# Altium schematic sheet main.SchDoc(main)
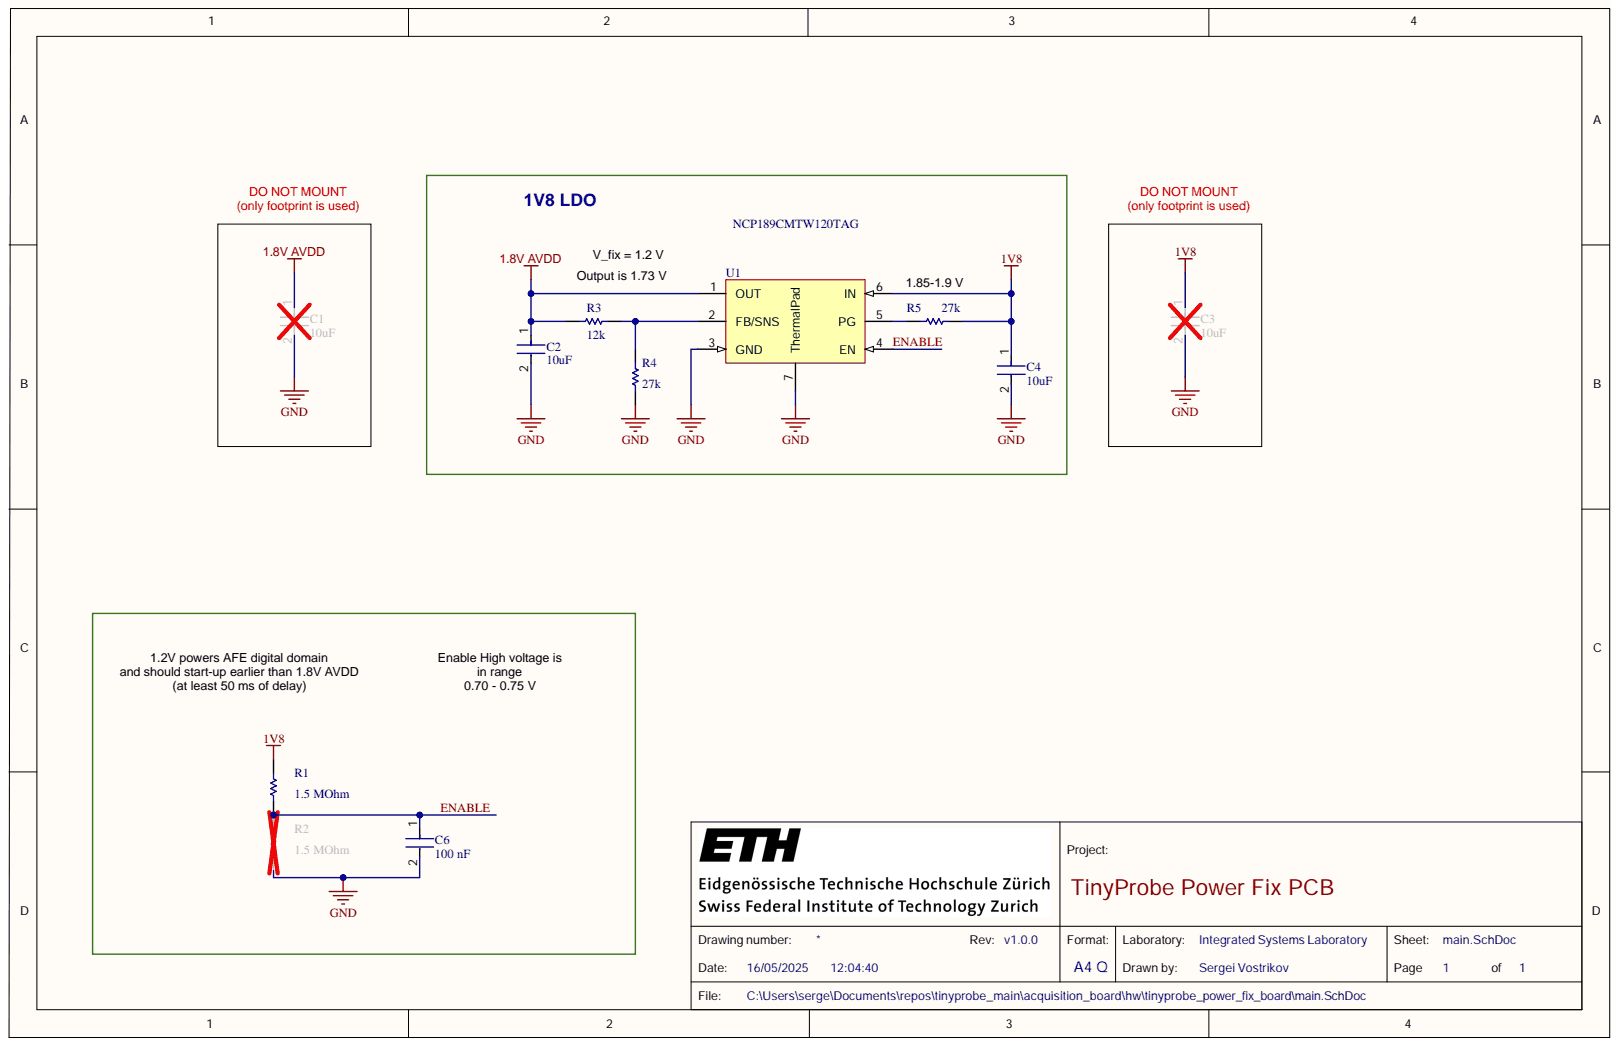
[diagram p0: main.SchDoc]

## Parts
C1  10uF  [FP-GRM188-0_1-e0_2_0_55-IPC_A]
C2  10uF  [FP-GRM188-0_1-e0_2_0_55-IPC_A]
C3  10uF  [FP-GRM188-0_1-e0_2_0_55-IPC_A]
C4  10uF  [FP-GRM188-0_1-e0_2_0_55-IPC_A]
C6  100 nF  [FP-C0402-BB-MFG]
R1  1.5 MOhm  [RESC1005X40X25NL05T10]
R2  1.5 MOhm  [RESC1005X40X25NL05T10]
R3  12k  [RESC1005X40X25LL05T05]
R4  27k  [RESC1005X40X25LL10T10]
R5  27k  [RESC1005X40X25LL10T10]
U1  NCP189CMTW120TAG  [NCP189]

## Nets
1.8V AVDD: C1.1 C2.1 R3.1 U1.1(OUT)
1V8: C3.1 C4.1 R1.2 R5.1 U1.6(IN)
GND: C1.2 C2.2 C3.2 C4.2 C6.2 R2.1 R4.1 U1.3(GND) U1.7(ThermalPad)
ENABLE: C6.1 R1.1 R2.2 U1.4(EN)
NetR3_2: R3.2 R4.2 U1.2(FB/SNS)
NetR5_2: R5.2 U1.5(PG)

## Library data of the parts
C1-C4 = CMP-2006-02792-2: Chip Multilayer Ceramic Capacitors for General Purpose, 0603, 10uF, X5R, 15%, 20%, 6.3V; Capacitance: 10uF; Case Code (Imperial): 0603; Case Code (Metric): 1608; Case/Package: 0603; Component Kind: Standard; Depth: 0.8mm; Dielectric: X5R; Height: 0.8mm; Lead Free: Lead Free; Length: 1.6mm; Library Name: Altium Content Vault; Material: Ceramic; Max Operating Temperature: 85°C; Min Operating Temperature: -55°C; Mount: Surface Mount; Package Quantity: 4000; Packaging: Tape and Reel; Radiation Hardening: No; REACH SVHC: No SVHC; Termination: SMD/SMT; Thickness: 0.035inch; Tolerance: 20%; Voltage: 6.3V; Voltage Rating: 6.3V; Voltage Rating (DC): 6.3V; Width: 0.031inch
C6 = CMP-2008-02046-2: CAP CER 0.1UF 10V X5R 0402  C0402C104K8PACTU; Manufacturer: Kemet; Capacitance: 0.10 μF; Material: Ceramic; Temperature Coefficient: 15%; Tolerance: 0.1; Value: 0.10 μF; Automotive: No; Category: Cap; Component Kind: Standard; ComponentLink2Description: Datasheet; ComponentLink2URL: https://content.kemet.com/datasheets/KEM_C1002_X7R_SMD.pdf; Device Class L1: Passive Components; Device Class L2: Capacitors; Device Class L3: Ceramic Capacitors; Digikey Description: CAP CER 0.1UF 10V X5R 0402; Height: 0.55mm; Ipc Land Pattern Name: CAPC10050X50; Lead Free: Yes; Library Name: Altium Content Vault; Manufacturer Part Number: C0402C104K8PACTU; Mouser Description: Capacitor 100 nF 10 VDC 0402; Octopart: https://octopart.com/c0402c104k8pactu-kemet-140039; Package: C0402-BB; RoHS: TRUE; Temperature: -55°C to +125°C; Temperature Characteristic: X5R; Temperature Range High: +125°C; Temperature Range Low: -55°C; Voltage Rating: 10 V
R1 R2 = CMP-2002-07106-1: RC0402FR-071M5L; Manufacturer: Yageo / Phycomp; Component Kind: Standard; Library Name: Altium Content Vault; Part Number: RC0402FR-071M5L
R3 = CMP-2002-00646-4: ERJ-2RKF1202X; Case\/Package: 0402; Power Rating: 100 mW; Resistance: 12 KOhm; Tolerance: 1%; Voltage Rating: 50 V; Case Code (Imperial): 0402; Case Code (Metric): 1005; Component Kind: Standard; ComponentLink1Description: Manufacturer URL; ComponentLink1URL: http://www.panasonic.com/; ComponentLink2Description: Datasheet; ComponentLink2URL: http://industrial.panasonic.com/cdbs/www-data/pdf/RDA0000/AOA0000C86.pdf; DatasheetVersion: 04/2015; Height: 0.35 mm; Length: 1 mm; Library Name: Altium Content Vault; Max Operating Temperature: 155 degC; Min Operating Temperature: -55 degC; Mount: Surface Mount; Mounting Technology: Surface Mount; Package Quantity: 10000; PackageDescription: 2-Pin Surface Mount Device, Body 1 x 0.5 mm; PackageReference: 0402; Packaging: Tape and Reel; PartNumber: ERJ-2RKF1202X; Pins: 2; REACH SVHC: Yes; RoHSCompliant: true; Width: 0.5 mm
R4 R5 = CMP-2002-05797-1: ERA-2AEB273X; Manufacturer: Panasonic; Component Kind: Standard; Library Name: Altium Content Vault; Part Number: ERA-2AEB273X
U1 = NCP189CMTW120TAG: LDO Regulator, 0.5 A, High  Accuracy (0.7%), Adjustable,  Low Noise, High PSRR with  Power Good; Component Kind: Standard; Library Name: power_board_evm.SCHLIB
